# Altium schematic sheet NRF24L01.SchDoc(NRF24L01)
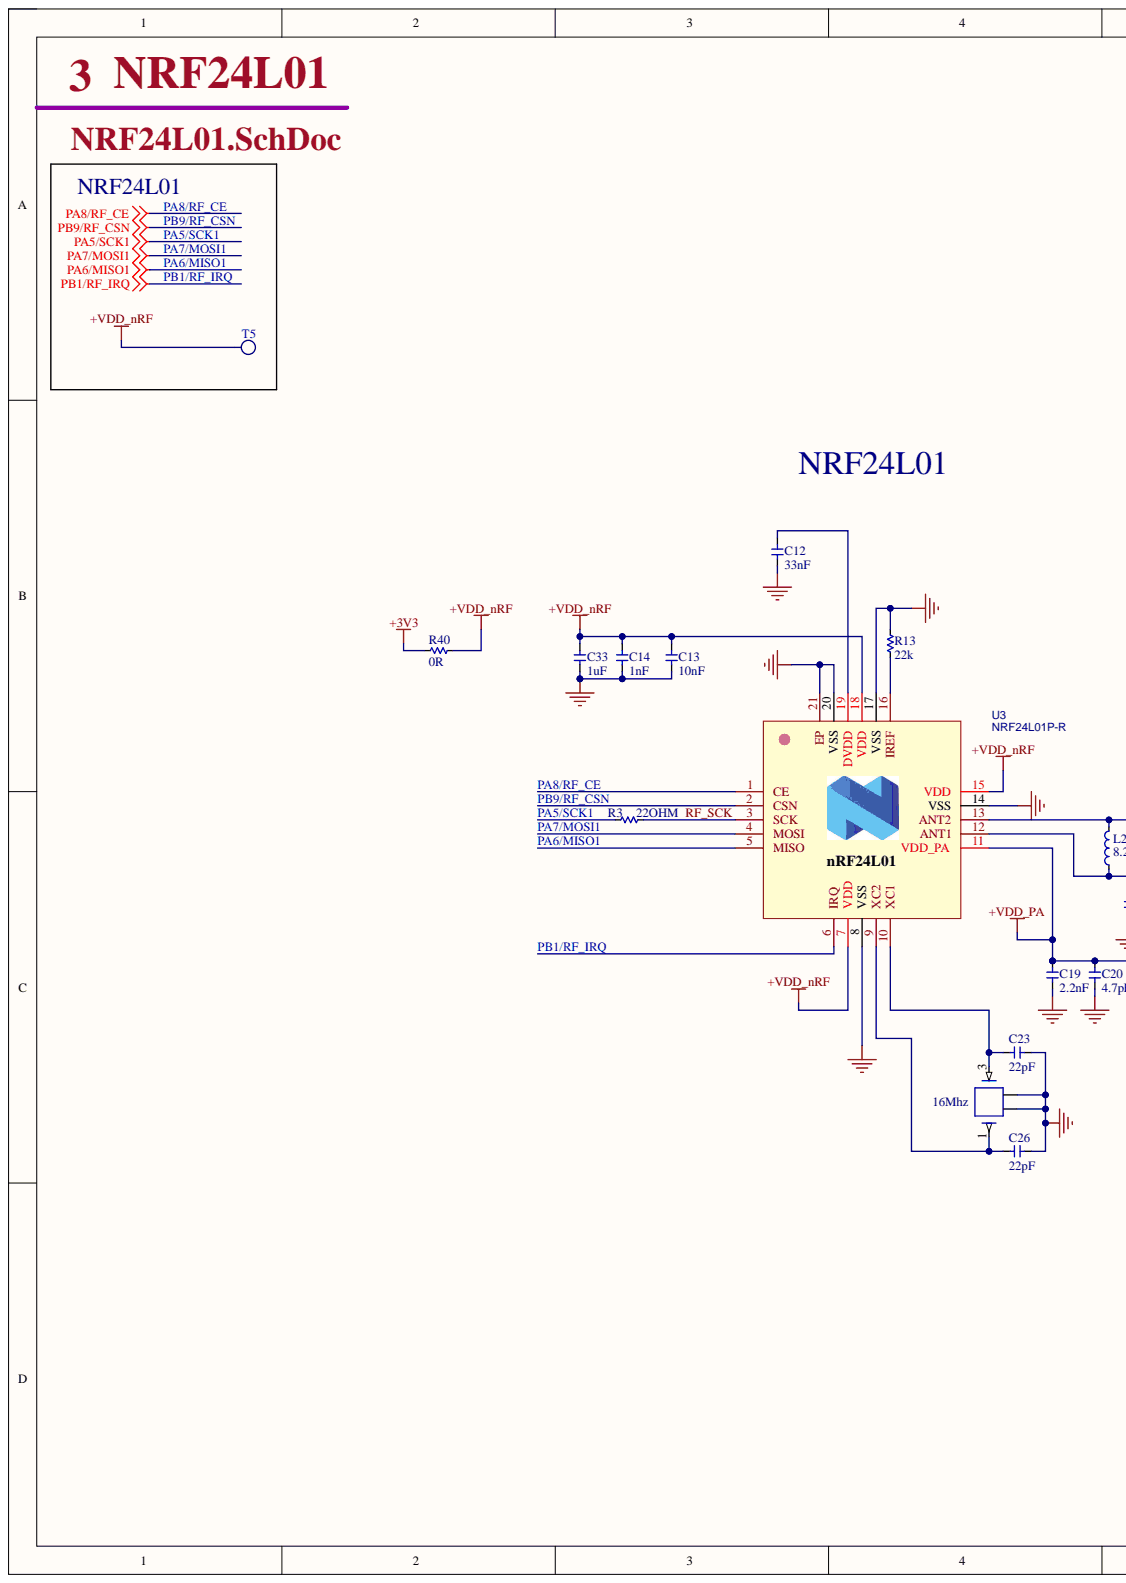
[diagram p0: NRF24L01.SchDoc, left]
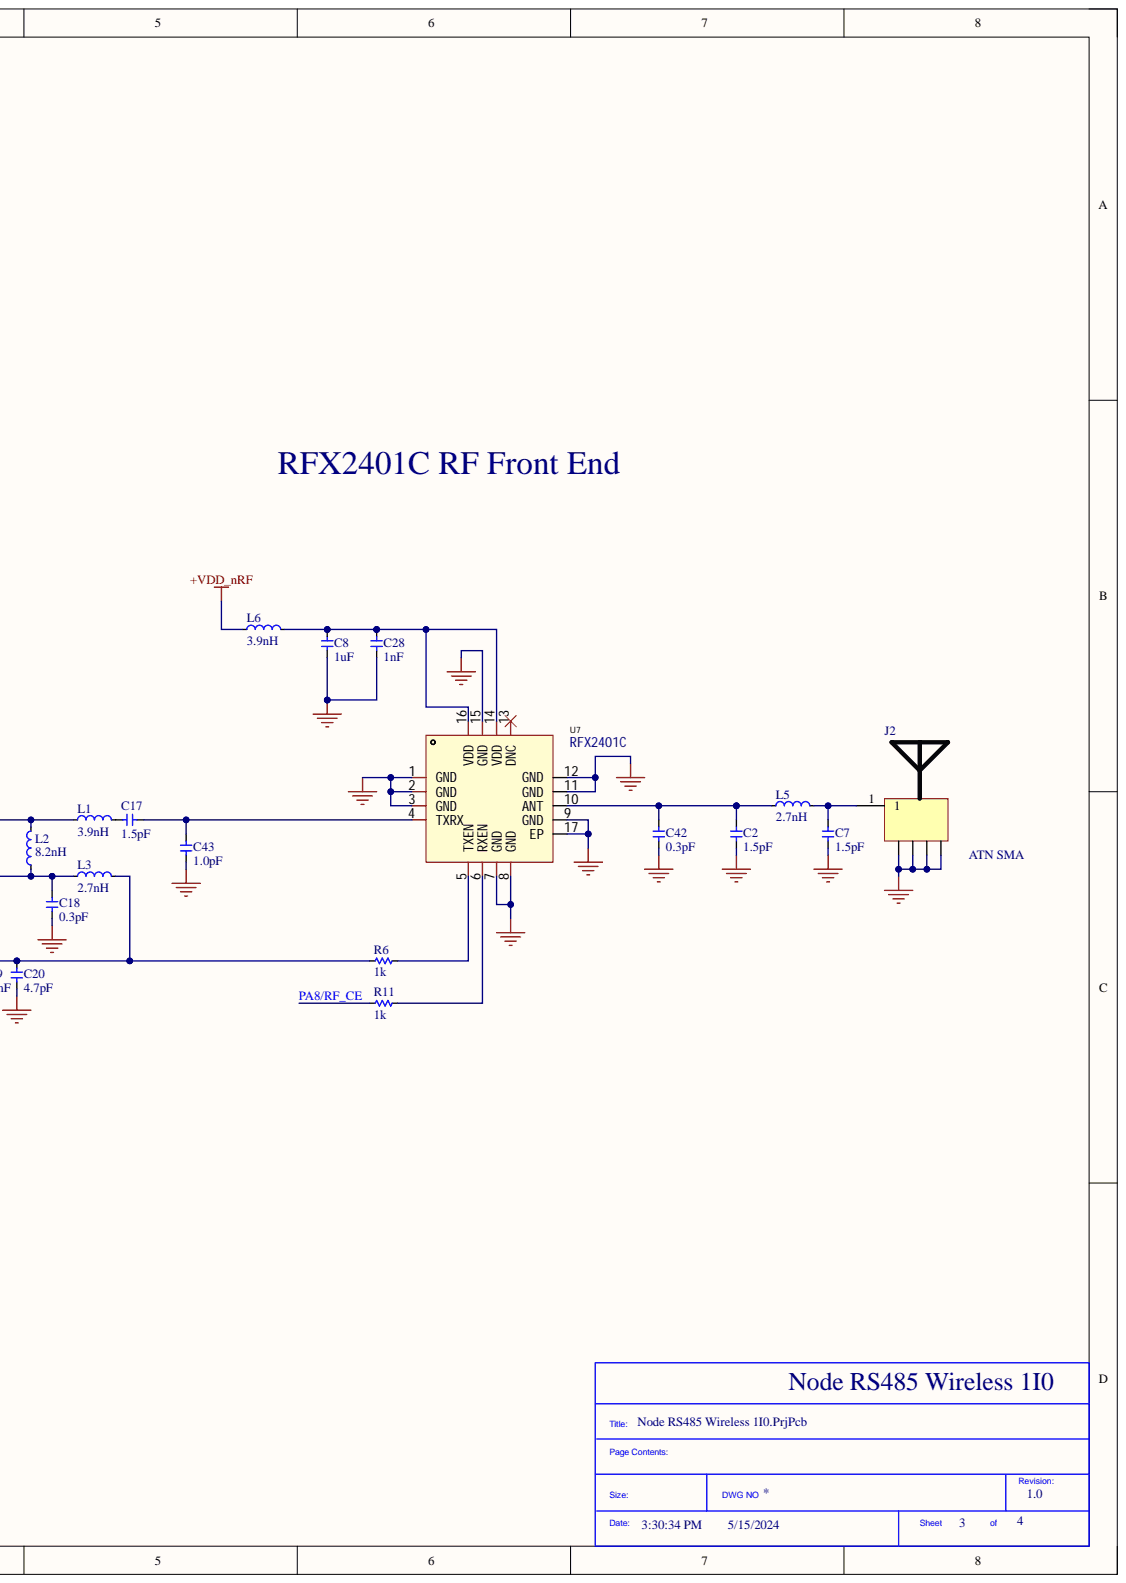
[diagram p1: NRF24L01.SchDoc, right]

## Parts
C2  1.5pF  [C_0402]
C7  1.5pF  [C_0402]
C8  1uF  [C_0402]
C12  33nF  [C_0402]
C13  10nF  [C_0402]
C14  1nF  [C_0402]
C17  1.5pF  [C_0402]
C18  0.3pF  [C_0402]
C19  2.2nF  [C_0402]
C20  4.7pF  [C_0402]
C23  22pF  [C_0402]
C26  22pF  [C_0402]
C28  1nF  [C_0402]
C33  1uF  [C_0402]
C42  0.3pF  [C_0402]
C43  1.0pF  [C_0402]
J2  ATN SMA  [ANTEN_SMA]
L1  3.9nH  [L_0402]
L2  8.2nH  [L_0402]
L3  2.7nH  [L_0402]
L5  2.7nH  [L_0402]
L6  3.9nH  [L_0402]
R3  22OHM  [R_0402]
R6  1k  [R_0402]
R11  1k  [R_0402]
R13  22k  [R_0402]
R40  0R  [R_0402]
T5  TEST-POINT'4545'  [TP-D45MIL]  (value not drawn)  (no BOM)
U3  NRF24L01P-R  [QFN-20_L4.0-W4.0-P0.50-BL-EP]
U7  RFX2401C  [QFN-16_L3.0-W3.0-P0.50-BL-EP1.7]
X3  16Mhz  [SMD-3225_4P]

## Nets
+3V3: R40.2
+VDD_nRF: C13.2 C14.1 C33.2 L6.1 R40.1 T5.T U3.7(VDD) U3.15(VDD) U3.18(VDD)
+VDD_PA: C19.2 C20.2 L3.2 R6.2 U3.11(VDD_PA)
GND: C2.1 C7.1 C8.1 C12.1 C13.1 C14.2 C18.1 C19.1 C20.1 C23.1 C26.1 C28.2 C33.1 C42.1 C43.1 J2.2 J2.3 J2.4 J2.5 R13.1 U3.8(VSS) U3.14(VSS) U3.17(VSS) U3.20(VSS) U3.21(EP) U7.1(GND) U7.2(GND) U7.3(GND) U7.7(GND) U7.8(GND) U7.9(GND) U7.11(GND) U7.12(GND) U7.15(GND) U7.17(EP) X3.2 X3.4
PA5/SCK1: R3.2
PA6/MISO1: U3.5(MISO)
PA7/MOSI1: U3.4(MOSI)
PA8/RF_CE: R11.2 U3.1(CE)
PB1/RF_IRQ: U3.6(IRQ)
PB9/RF_CSN: U3.2(CSN)
RF_SCK: R3.1 U3.3(SCK)
NetC2_2: C2.2 C42.2 L5.1 U7.10(ANT)
NetC7_2: C7.2 J2.1 L5.2
NetC8_2: C8.2 C28.1 L6.2 U7.14(VDD) U7.16(VDD)
NetC12_2: C12.2 U3.19(DVDD)
NetC17_1: C17.1 L1.2
NetC17_2: C17.2 C43.2 U7.4(TXRX)
NetC18_2: C18.2 L2.1 L3.1 U3.12(ANT1)
NetC23_2: C23.2 U3.10(XC1) X3.3
NetC26_2: C26.2 U3.9(XC2) X3.1
NetL1_1: L1.1 L2.2 U3.13(ANT2)
NetR6_1: R6.1 U7.5(TXEN)
NetR11_1: R11.1 U7.6(RXEN)
NetR13_2: R13.2 U3.16(IREF)

Not connected: U7 13(DNC)

## Library data of the parts
C2 C7 C17 = GJM1555C1H1R5WB01D: 1.5pF 50V C0G 0402  Multilayer Ceramic Capacitors MLCC - SMD/SMT ROHS; Manufacturer: Murata Electronics; Assembly Type: SMT Assembly; Component Kind: Standard; ComponentLink1Description: Digikey Link; ComponentLink2Description: LCSC Link; ComponentLink2URL: https://jlcpcb.com/parts/componentSearch?searchTxt=10k%200603; LCSC Part Number: C76901; Library Name: Node RS485 Wireless 1I0.SCHLIB; Manufacturer Part Number: GJM1555C1H1R5WB01D; Package: 0402
C8 C33 = CL05A105KA5NQNC: 25V 1uF X5R ±10% 0402 Multilayer Ceramic Capacitors MLCC - SMD/SMT ROHS; Manufacturer: Samsung Electro-Mechanics; Assembly Type: SMT Assembly; Component Kind: Standard; ComponentLink1Description: Digikey Link; ComponentLink1URL: https://www.digikey.com/en/products/detail/yageo/CC0603KRX7R9BB104/2103082?s=N4IgTCBcDaIMwEYEFoFwCzuWZA5AIiALoC%2BQA; ComponentLink2Description: LCSC Link; ComponentLink2URL: https://jlcpcb.com/parts/componentSearch?searchTxt=10k%200603; LCSC Part Number: C52923; Library Name: Node RS485 Wireless 1I0.SCHLIB; Manufacturer Part Number: CL05A105KA5NQNC; Package: 0402
C12 = CC0402KRX7R9BB333: 50V 33nF X7R ±10% 0402 Multilayer Ceramic Capacitors MLCC - SMD/SMT ROHS; Manufacturer: YAGEO; Assembly Type: SMT Assembly; Component Kind: Standard; ComponentLink1Description: Digikey Link; ComponentLink2Description: LCSC Link; ComponentLink2URL: https://jlcpcb.com/parts/componentSearch?searchTxt=10k%200603; LCSC Part Number: C106862; Library Name: Dev Board STM OLED 2.0.SCHLIB; Manufacturer Part Number: CC0402KRX7R9BB333; Package: 0402
C13 = 0402B103K500NT: 10nF 50V X7R ±10% 0402 Multilayer Ceramic Capacitors MLCC - SMD/SMT ROH; Manufacturer: FH(Guangdong Fenghua Advanced Tech); Manufacturer Part Number: 0402CG120J500NT; Assembly Type: SMT Assembly; Component Kind: Standard; ComponentLink1Description: Digikey Link; ComponentLink2Description: LCSC Link; ComponentLink2URL: https://jlcpcb.com/parts/componentSearch?searchTxt=10k%200603; LCSC Part Number: C1547; Library Name: Dev Board STM OLED 2.0.SchLib; Package: 0402
C14 C28 = 0402B102K500NT: 1nF 50V X7R ±10% 0402 Multilayer Ceramic Capacitors MLCC - SMD/SMT ROHS; Manufacturer: FH(Guangdong Fenghua Advanced Tech); Assembly Type: SMT Assembly; Component Kind: Standard; ComponentLink1Description: Digikey Link; ComponentLink2Description: LCSC Link; ComponentLink2URL: https://jlcpcb.com/parts/componentSearch?searchTxt=10k%200603; LCSC Part Number: C1523; Library Name: Dev Board STM OLED 2.0.SchLib; Manufacturer Part Number: 0402B102K500NT; Package: 0402
C18 C42 = GJM1555C1HR30BB01D: 0.3pF 50V C0G 0402  Multilayer Ceramic Capacitors MLCC - SMD/SMT ROHS; Manufacturer: Murata Electronics; Assembly Type: SMT Assembly; Component Kind: Standard; ComponentLink1Description: Digikey Link; ComponentLink2Description: LCSC Link; ComponentLink2URL: https://jlcpcb.com/parts/componentSearch?searchTxt=10k%200603; LCSC Part Number: C85885; Library Name: Node RS485 Wireless 1I0.SCHLIB; Manufacturer Part Number: GJM1555C1HR30BB01D; Package: 0402
C19 = 0402B222K500NT: 2.2nF 50V C0G ±5% 0402 Multilayer Ceramic Capacitors MLCC - SMD/SMT ROHS; Manufacturer: FH(Guangdong Fenghua Advanced Tech); Assembly Type: SMT Assembly; Component Kind: Standard; ComponentLink1Description: Digikey Link; ComponentLink2Description: LCSC Link; ComponentLink2URL: https://jlcpcb.com/parts/componentSearch?searchTxt=10k%200603; LCSC Part Number: C1531; Library Name: Dev Board STM OLED 2.0.SchLib; Manufacturer Part Number: 0402B222K500NT; Package: 0402
C20 = 0402CG4R7C500NT: 4.7pF 50V C0G ±5% 0402 Multilayer Ceramic Capacitors MLCC - SMD/SMT ROHS; Manufacturer: FH(Guangdong Fenghua Advanced Tech); Assembly Type: SMT Assembly; Component Kind: Standard; ComponentLink1Description: Digikey Link; ComponentLink2Description: LCSC Link; ComponentLink2URL: https://jlcpcb.com/parts/componentSearch?searchTxt=10k%200603; LCSC Part Number: C1569; Library Name: Dev Board STM OLED 2.0.SCHLIB; Manufacturer Part Number: 0402CG4R7C500NT; Package: 0402
C23 C26 = 0402CG220J500NT: 22pF 50V C0G ±5% 0402 Multilayer Ceramic Capacitors MLCC - SMD/SMT ROHS; Manufacturer: FH(Guangdong Fenghua Advanced Tech); Assembly Type: SMT Assembly; Component Kind: Standard; ComponentLink1Description: Digikey Link; ComponentLink2Description: LCSC Link; ComponentLink2URL: https://jlcpcb.com/parts/componentSearch?searchTxt=10k%200603; LCSC Part Number: C1555; Library Name: Dev Board STM OLED 2.0.SCHLIB; Manufacturer Part Number: 0402CG220J500NT; Package: 0402
C43 = GRM1555C1H1R0CA01D: 1.0pF 50V C0G 0402  Multilayer Ceramic Capacitors MLCC - SMD/SMT ROHS; Manufacturer: Murata Electronics; Assembly Type: SMT Assembly; Component Kind: Standard; ComponentLink1Description: Digikey Link; ComponentLink2Description: LCSC Link; ComponentLink2URL: https://jlcpcb.com/parts/componentSearch?searchTxt=10k%200603; LCSC Part Number: C76953; Library Name: Node RS485 Wireless 1I0.SCHLIB; Manufacturer Part Number: GRM1555C1H1R0CA01D; Package: 0402
J2 = Antena_SMA: Connector; SMB Straight; Assembly Type: Hand Soldering; Component Kind: Standard; LCSC Part Number: N/A; Library Name: Node RS485 Wireless 1I0.SCHLIB; Manufacturer: N/A; Manufacturer Part Number: N/A; Package: Through Hole
L1 L6 = FHW0402UC3N9JST: 3.9nH 840mA ±5% 66mΩ 0402 Inductors (SMD) ROHS; Manufacturer: FH(Guangdong Fenghua Advanced Tech); Assembly Type: SMT Assembly; Component Kind: Standard; LCSC Part Number: C317939; Library Name: Dev Board STM OLED 2.0.SCHLIB; Manufacturer Part Number: FHW0402UC3N9JST; Package: 0402
L2 = FHW0402UC8N2JST: 8.2nH 680mA ±5% 100mΩ 0402 Inductors (SMD) ROHS; Manufacturer: FH(Guangdong Fenghua Advanced Tech); Assembly Type: SMT Assembly; Component Kind: Standard; LCSC Part Number: C317946; Library Name: Dev Board STM OLED 2.0.SCHLIB; Manufacturer Part Number: FHW0402UC8N2JST; Package: 0402
L3 L5 = FHW0402UC2N7KST: 2.7nH 640mA  ±10% 120mΩ 0402 Inductors (SMD) ROHS; Manufacturer: FH(Guangdong Fenghua Advanced Tech); Assembly Type: SMT Assembly; Component Kind: Standard; LCSC Part Number: C317935; Library Name: Dev Board STM OLED 2.0.SchLib; Manufacturer Part Number: FHW0402UC2N7KST; Package: 0402
R3 = 0402WGF220JTCE: 22Ω 0402 62.5mW Thick Film Resistors 50V ±100ppm/℃ ±1% -55℃~+155℃; Manufacturer: Uniroyal Elec; Assembly Type: SMT Assembly; Component Kind: Standard; LCSC Part Number: C25092; Library Name: Dev Board STM OLED 2.0.SCHLIB; Manufacturer Part Number: 0402WGF220JTCE; Package: 0402
R6 R11 = 0402WGF1001TCE: 1kΩ 0402 62.5mW Thick Film Resistors 50V ±100ppm/℃ ±1% -55℃~+155℃; Manufacturer: Uniroyal Elec; Assembly Type: SMT Assembly; Component Kind: Standard; LCSC Part Number: C11702; Library Name: Node RS485 Wireless 1I0.SCHLIB; Manufacturer Part Number: 0402WGF1001TCE; Package: 0402
R13 = 0402WGF2202TCE: 22k 0402 62.5mW Thick Film Resistors 50V ±100ppm/℃ ±1% -55℃~+155℃ 22kΩ; Manufacturer: Uniroyal Elec; Assembly Type: SMT Assembly; Component Kind: Standard; LCSC Part Number: C25768; Library Name: Dev Board STM OLED 2.0.SchLib; Manufacturer Part Number: 0402WGF2202TCE; Package: 0402
R40 = 0402WGF0000TCE: 0R 0Ω 0402 1% Chip Resistor - Surface Mount ROHS; Manufacturer: Uniroyal Elec; Assembly Type: SMT Assembly; Component Kind: Standard; LCSC Part Number: C17168; Library Name: Node RS485 Wireless 1I0.SCHLIB; Manufacturer Part Number: 0402WGF0000TCE; Package: 0402
T5 = TEST-POINT'4545': Manufacturer: No; Description: TEST-POINT'4545'; LCSC Part Number: No; Library Name: Node RS485 Wireless 1I0.SCHLIB; Manufacturer Part Number: No; Package: No
U3 = NRF24L01P-R: NRF24L01P-R SPI QFN-20_4x4x05P RF Transceiver ICs ROHS; Manufacturer: NORDIC; Assembly Type: SMT Assembly; Component Kind: Standard; LCSC Part Number: C8791; Library Name: AK Base Kit 2.2.SCHLIB; Manufacturer Part Number: NRF24L01P-R; Package: QFN-20_4x4x05P
U7 = RFX2401C: ERX2401C 2.4GHz~2.5GHz QFN-16-EP(3x3)  RF Front End Circuits ROHS; Manufacturer: SKYWORKS; Add into BOM: yes; Applications: 802.15.4,Zigbee; Assembly Type: SMT Assembly; Component Kind: Standard; Convert to PCB: yes; Datasheet: https://atta.szlcsc.com/upload/public/pdf/source/20130922/1457706649469.pdf; Device: RFX2401C; Frequency Range: 2.4GHz~2.5GHz; LCSC Part Name: 2.4GHz ZIGBEE/ISM发送/接收射频前端集成电路; LCSC Part Number: C19213; Library Name: Node RS485 Wireless 1I0.SCHLIB; Manufacturer Part Number: RFX2401C; Package: QFN-16-EP(3x3); Supplier: LCSC; Symbol: RFX2401C; Value: 2.4GHz ~ 2.5GHz
X3 = X322516MLB4SI: 16MHz SMD Crystal Resonator 9pF ±10ppm ±30ppm -40℃~+85℃ SMD3225-4P Crystals ROHS; Manufacturer: Yangxing Tech; Assembly Type: SMT Assembly; Component Kind: Standard; LCSC Part Number: C13738; Library Name: Dev Board STM OLED 2.0.SchLib; Manufacturer Part Number: X322516MLB4SI; Package: SMD-3225_4P
